# Altium schematic sheet pca20027 - radio.SchDoc
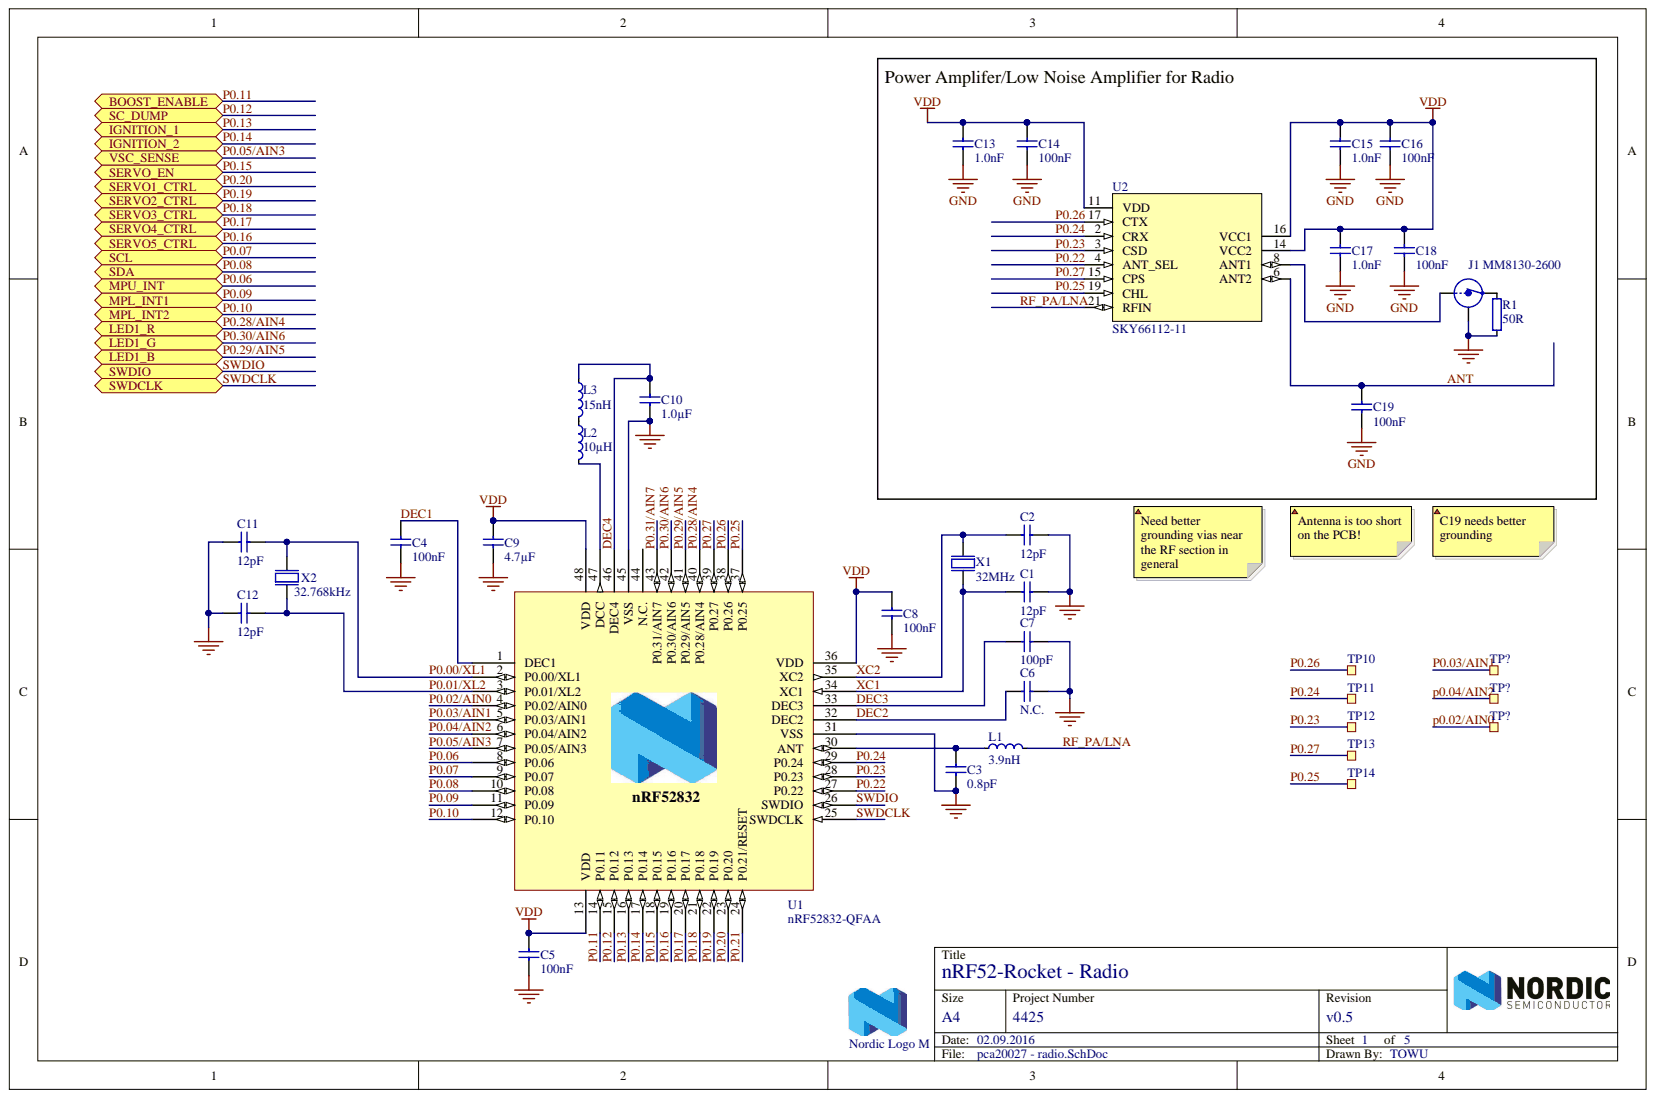
[diagram p0: pca20027 - radio.SchDoc]

## Parts
C1  12pF  [CAPC1005X04L]
C2  12pF  [CAPC1005X04L]
C3  0.8pF  [CAPC1005X04L]
C4  100nF  [CAPC1005X04L]
C5  100nF  [CAPC1005X04L]
C6  N.C.  [CAPC1005X04L]
C7  100pF  [CAPC1005X04L]
C8  100nF  [CAPC1005X04L]
C9  4.7µF  [CAPC1608X06L]
C10  1.0µF  [CAPC1608X06L]
C11  12pF  [CAPC1005X04L]
C12  12pF  [CAPC1005X04L]
C13  1.0nF  [CAPC1005X04L]
C14  100nF  [CAPC1005X04L]
C15  1.0nF  [CAPC1005X04L]
C16  100nF  [CAPC1005X04L]
C17  1.0nF  [CAPC1005X04L]
C18  100nF  [CAPC1005X04L]
C19  100nF  [CAPC1005X04L]
J1  MM8130-2600  [COAXIAL-SWF]
L1  3.9nH  [INDC1005X04L]
L2  10µH  [INDC1608X06L]
L3  15nH  [INDC1005X04L]
LOGO1  Nordic Logo M  [NORDIC_LOGO_M]  (no BOM)
R1  50R  [RESC1005X04L]
TP10  Ø1.0mm,SMD  [TP_SMD_Ø1.0mm]  (value not drawn)  (no BOM)
TP11  Ø1.0mm,SMD  [TP_SMD_Ø1.0mm]  (value not drawn)  (no BOM)
TP12  Ø1.0mm,SMD  [TP_SMD_Ø1.0mm]  (value not drawn)  (no BOM)
TP13  Ø1.0mm,SMD  [TP_SMD_Ø1.0mm]  (value not drawn)  (no BOM)
TP14  Ø1.0mm,SMD  [TP_SMD_Ø1.0mm]  (value not drawn)  (no BOM)
TP?  Ø1.0mm,SMD  [TP_SMD_Ø1.0mm]  (value not drawn)  (no BOM)
TP?  Ø1.0mm,SMD  [TP_SMD_Ø1.0mm]  (value not drawn)  (no BOM)
TP?  Ø1.0mm,SMD  [TP_SMD_Ø1.0mm]  (value not drawn)  (no BOM)
U1  nRF52832-QFAA  [QFN40P600X600X90-48N]
U2  SKY66112-11  [SKY66112-11]
X1  32MHz  [BT-XTAL_2016]
X2  32.768kHz  [XTAL_3215]

## Ports
BOOST_ENABLE, IGNITION_1, IGNITION_2, LED1_B, LED1_G, LED1_R, MPL_INT1, MPL_INT2, MPU_INT, SC_DUMP, SCL, SDA, SERVO1_CTRL, SERVO2_CTRL, SERVO3_CTRL, SERVO4_CTRL, SERVO5_CTRL, SERVO_EN, SWDCLK, SWDIO, VSC_SENSE

## Nets
GND: C1.2 C2.2 C3.1 C4.2 C5.2 C6.1 C7.2 C8.2 C9.2 C10.1 C11.2 C12.2 C13.1 C14.2 C15.1 C16.2 C17.1 C18.2 C19.2 J1.2 R1.1 U1.31(VSS) U1.45(VSS) U1.49 U2.1 U2.5 U2.7 U2.9 U2.10 U2.12 U2.13 U2.18 U2.20 U2.22 U2.23 X1.2 X1.4
VDD: C5.1 C8.1 C9.1 C13.2 C14.1 C15.2 C16.1 C17.2 C18.1 U1.13(VDD) U1.36(VDD) U1.48(VDD) U2.11(VDD) U2.14(VCC2) U2.16(VCC1)
ANT: C19.1 U2.6(ANT2)
DEC1: C4.1 U1.1(DEC1)
DEC2: C6.2 U1.32(DEC2)
DEC3: C7.1 U1.33(DEC3)
DEC4: C10.2 L3.1 U1.46(DEC4)
P0.00/XL1: C11.1 U1.2(P0.00/XL1) X2.1
P0.01/XL2: C12.1 U1.3(P0.01/XL2) X2.2
P0.02/AIN0: TP?.1 U1.4(P0.02/AIN0)
P0.03/AIN1: TP?.1 U1.5(P0.03/AIN1)
P0.04/AIN2: TP?.1 U1.6(P0.04/AIN2)
P0.05/AIN3: U1.7(P0.05/AIN3) ; port VSC_SENSE
P0.06: U1.8(P0.06) ; port MPU_INT
P0.07: U1.9(P0.07) ; port SCL
P0.08: U1.10(P0.08) ; port SDA
P0.09: U1.11(P0.09) ; port MPL_INT1
P0.10: U1.12(P0.10) ; port MPL_INT2
P0.11: U1.14(P0.11) ; port BOOST_ENABLE
P0.12: U1.15(P0.12) ; port SC_DUMP
P0.13: U1.16(P0.13) ; port IGNITION_1
P0.14: U1.17(P0.14) ; port IGNITION_2
P0.15: U1.18(P0.15) ; port SERVO_EN
P0.16: U1.19(P0.16) ; port SERVO5_CTRL
P0.17: U1.20(P0.17) ; port SERVO4_CTRL
P0.18: U1.21(P0.18) ; port SERVO3_CTRL
P0.19: U1.22(P0.19) ; port SERVO2_CTRL
P0.20: U1.23(P0.20) ; port SERVO1_CTRL
P0.21: U1.24(P0.21/RESET)
P0.22: U1.27(P0.22) U2.4(ANT_SEL)
P0.23: TP12.1 U1.28(P0.23) U2.3(CSD)
P0.24: TP11.1 U1.29(P0.24) U2.2(CRX)
P0.25: TP14.1 U1.37(P0.25) U2.19(CHL)
P0.26: TP10.1 U1.38(P0.26) U2.17(CTX)
P0.27: TP13.1 U1.39(P0.27) U2.15(CPS)
P0.28/AIN4: U1.40(P0.28/AIN4) ; port LED1_R
P0.29/AIN5: U1.41(P0.29/AIN5) ; port LED1_B
P0.30/AIN6: U1.42(P0.30/AIN6) ; port LED1_G
P0.31/AIN7: U1.43(P0.31/AIN7)
RF_PA/LNA: L1.2 U2.21(RFIN)
SWDCLK: U1.25(SWDCLK) ; port SWDCLK
SWDIO: U1.26(SWDIO) ; port SWDIO
XC1: C1.1 U1.34(XC1) X1.1
XC2: C2.1 U1.35(XC2) X1.3
NetC3_2: C3.2 L1.1 U1.30(ANT)
NetJ1_1: J1.1 U2.8(ANT1)
NetJ1_3: J1.3 R1.2
NetL2_1: L2.1 L3.2
NetL2_2: L2.2 U1.47(DCC)

Not connected: U1 44(N.C.)

## Library data of the parts
C1 C2 C11 C12 = C0402-12p: Capacitor, NP0, ±2%; Artikkelnr Noca: 20029159; Artikkelnr Simpro: N.A.; Database Table Name: Capacitors$; Note: 50V; Component Kind: Standard; Footprint Doc: 0402; LatestRevisionDate: 2011-05-11; Library Name: Nordic Passive DB.DbLib; Part Number: C0402-12p; Pin Count: 2; Published: 2009-05-08; Value: 12pF; Publisher: Nordic Semiconductor
C3 = C0402-0.8p: Capacitor, NP0, ±5%; Artikkelnr Noca: 20029147; Artikkelnr Simpro: N.A.; Database Table Name: Capacitors$; Note: 50V; Component Kind: Standard; Footprint Doc: 0402; LatestRevisionDate: 2012-11-21; Library Name: Nordic Passive DB.DbLib; Part Number: C0402-0.8p; Pin Count: 2; Published: 2009-05-08; Value: 0.8pF; Publisher: Nordic Semiconductor
C4 C5 C8 C14 C16 C18 C19 = C0402-100n: Capacitor, X7R, ±10%; Artikkelnr Noca: 20039200; Artikkelnr Simpro: N.A.; Database Table Name: Capacitors$; Note: 16V; Component Kind: Standard; Footprint Doc: 0402; LatestRevisionDate: 2009-11-23; Library Name: Nordic Passive DB.DbLib; Part Number: C0402-100n; Pin Count: 2; Published: 2009-05-08; Value: 100nF; Publisher: Nordic Semiconductor
C6 = C0402-N.C.: Not mounted; Artikkelnr Noca: N.A.; Database Table Name: Capacitors$; Note: Chip Cap; Artikkelnr Simpro: N.A.; Component Kind: Standard; Footprint Doc: 0402; LatestRevisionDate: 2009-05-08; Library Name: Nordic Passive DB.DbLib; Part Number: C0402-N.C.; Pin Count: 2; Published: 2009-05-08; Value: N.C.; Publisher: Nordic Semiconductor
C7 = C0402-100p: Capacitor, NP0, ±5%; Artikkelnr Noca: 20029135; Artikkelnr Simpro: N.A.; Database Table Name: Capacitors$; Note: 50V; Component Kind: Standard; Footprint Doc: 0402; LatestRevisionDate: 2009-05-08; Library Name: Nordic Passive DB.DbLib; Part Number: C0402-100p; Pin Count: 2; Published: 2009-05-08; Value: 100pF; Publisher: Nordic Semiconductor
C9 = C0603-4µ7: Capacitor, X5R, ±10%; Artikkelnr Noca: 20069060; Artikkelnr Simpro: N.A.; Database Table Name: Capacitors$; Note: 6.3V; Component Kind: Standard; Footprint Doc: 0603; LatestRevisionDate: 2009-11-23; Library Name: Nordic Passive DB.DbLib; Part Number: C0603-4µ7; Pin Count: 2; Published: 2009-05-08; Value: 4.7µF; Publisher: Nordic Semiconductor
C10 = C0603-1µ0: Capacitor, X7R, ±10%; Artikkelnr Noca: 20039335; Artikkelnr Simpro: N.A.; Database Table Name: Capacitors$; Note: 16V; Component Kind: Standard; Footprint Doc: 0603; LatestRevisionDate: 2013-06-05; Library Name: Nordic Passive DB.DbLib; Part Number: C0603-1µ0; Pin Count: 2; Published: 2009-05-08; Value: 1.0µF; Publisher: Nordic Semiconductor
C13 C15 C17 = C0402-1n0: Capacitor, X7R, ±10%; Artikkelnr Noca: 20039223; Artikkelnr Simpro: N.A.; Database Table Name: Capacitors$; Note: 50V; Component Kind: Standard; Footprint Doc: 0402; LatestRevisionDate: 2009-11-23; Library Name: Nordic Passive DB.DbLib; Part Number: C0402-1n0; Pin Count: 2; Published: 2009-05-08; Value: 1.0nF; Publisher: Nordic Semiconductor
J1 = MM8130-2600: Coaxial Connector with Switch; 2nd source part number: 81-MM8130-2600RA2; Manufacturer: Murata; Artikkelnr Noca: 55029011; Artikkelnr Simpro: N.A.; Database Table Name: Connectors$; 2nd source Supplier: Mouser; Component Kind: Standard; Datasheet: http://www.murata.com/products/catalog/pdf/o33e.pdf; Footprint Doc: COAXIAL-SWF; LatestRevisionDate: 2014-04-24; Library Name: Nordic Passive DB.DbLib; Manufacturer Part Number: MM8130-2600; Part Number: MM8130-2600; Pin Count: 3; Published: 2014-04-24; Supplier: Digi-Key; Supplier part number: 490-4981-1-ND; Value: MM8130-2600; Publisher: Nordic Semiconductor
L1 = L0402-3n9: High frequency chip inductor ±5%; Manufacturer: Murata; Manufacturer Part Number: LQP15MN3N9B02D; Artikkelnr Noca: 33029151; Artikkelnr Simpro: N.A.; Database Table Name: Inductors$; Component Kind: Standard; Datasheet: http://search.murata.co.jp/Ceramy/image/img/PDF/ENG/L0075S0084LQP15M.pdf; Footprint Doc: 0402; LatestRevisionDate: 2012-09-19; Library Name: Nordic Passive DB.DbLib; Note: High frequency chip inductor ±5%; Part Number: L0402-3n9; Pin Count: 2; Published: 2009-05-08; Supplier: Digi-Key; Supplier part number: 490-1131-1-ND; Value: 3.9nH; Publisher: Nordic Semiconductor
L2 = L0603-10µ: Chip inductor, IDC,min = 50 mA, ±20%; 2nd source part number: 178-6105; Manufacturer: Taiyo Yuden; Manufacturer Part Number: LBMF1608T100K; Artikkelnr Noca: 33029314; Artikkelnr Simpro: N.A.; Database Table Name: Inductors$; Note: LBMF1608T100K 10µH 80mA 0603; 2nd source Supplier: Farnell; Component Kind: Standard; Datasheet: http://www.yuden.co.jp/ut/product/pdf/elb2_e.pdf; Footprint Doc: 0603; LatestRevisionDate: 2012-09-19; Library Name: Nordic Passive DB.DbLib; Part Number: L0603-10µ; Pin Count: 2; Published: 2010-02-24; Supplier: Digi-Key; Supplier part number: 587-1714-1-ND; Value: 10µH; Publisher: Nordic Semiconductor
L3 = L0402-15n: High frequency chip inductor ±10%; 2nd source part number: 658-1328; Manufacturer: Taiyo Yuden; Manufacturer Part Number: HK100515NJ-T; Artikkelnr Noca: 33029323; Artikkelnr Simpro: N.A.; Database Table Name: Inductors$; 2nd source Supplier: Farnell; Component Kind: Standard; Datasheet: http://www.yuden.co.jp/productdata/catalog/en/mlci04_e.pdf; Footprint Doc: 0402; LatestRevisionDate: 2012-09-19; Library Name: Nordic Passive DB.DbLib; Note: High frequency chip inductor ±10%; Part Number: L0402-15n; Pin Count: 2; Published: 2010-07-12; Supplier: Digi-Key; Supplier part number: 587-1521-1-ND; Value: 15nH; Publisher: Nordic Semiconductor
LOGO1 = Nordic Logo M: Nordic Logo, medium size; Artikkelnr Noca: N.A.; Database Table Name: Connectors$; Artikkelnr Simpro: N.A.; Footprint Doc: NORDIC_LOGO_M; LatestRevisionDate: 2010-02-18; Library Name: Nordic Passive DB.DbLib; Part Number: Nordic Logo M; Pin Count: 2; Published: 2010-02-18; Value: Nordic Logo M; Publisher: Nordic Semiconductor
R1 = R0402-50R: Resistor, ±1%, 0.063W; Artikkelnr Noca: N.A.; Database Table Name: Resistors$; Note: Chip Res 1%; Value Ohm: 50; Artikkelnr Simpro: N.A.; Component Kind: Standard; Footprint Doc: 0402; LatestRevisionDate: 2014-09-03; Library Name: Nordic Passive DB.DbLib; Part Number: R0402-50R; Pin Count: 2; Published: 2014-09-03; Value: 50R; Publisher: Nordic Semiconductor
TP10-TP14 TP? TP? TP? = TP_SMD_Ø1.0MM: 1.0mm circular SMD testpad; Manufacturer: Nordic Semiconductor; Artikkelnr Noca: N.A.; Database Table Name: Connectors$; Artikkelnr Simpro: N.A.; Footprint Doc: TP_SMD_Ø1.0mm; LatestRevisionDate: 2013-06-11; Library Name: Nordic Passive DB.DbLib; Part Number: TP_SMD_Ø1.0MM; Pin Count: 1; Published: 2013-06-11; Value: Ø1.0mm,SMD; Publisher: Nordic Semiconductor
U1 = nRF52832-QFAA: Multi-protocol Bluetooth Low Energy and 2.4GHz proprietary system-on-chip; Manufacturer: Nordic Semiconductor; Artikkelnr Noca: N.A.; Database Table Name: nRF Devices$; Artikkelnr Simpro: N.A.; Component Kind: Standard; Footprint Doc: QFN-48; LatestRevisionDate: 2014-11-26; Library Name: Nordic Active DB.DbLib; Manufacturer Part Number: nRF52832-QFAA; Part Number: nRF52832-QFAA; Pin Count: 48; Published: 2014-11-26; Supplier: Nordic Semiconductor; Value: nRF52832-QFAA; Publisher: Nordic Semiconductor
U2 = SKY66112-11: 2.4 GHz ZigBee®/Thread/Bluetooth® Smart Front-End Module; Manufacturer: Skyworks; Artikkelnr Noca: N.A.; Database Table Name: Misc IC$; Artikkelnr Simpro: N.A.; Component Kind: Standard; Datasheet: *Not available Online Yet; Footprint Doc: SKY66112-11; LatestRevisionDate: 2016-07-06; Library Name: Nordic Active DB.DbLib; Manufacturer Part Number: SKY66112-11; Part Number: SKY66112-11; Pin Count: 22; Published: 2016-07-06; Supplier: Skyworks; Supplier part number: SKY66112-11; Value: SKY66112-11; Publisher: Nordic Semiconductor
X1 = XTAL_SMD2016_32MHz_8pF_Epson: XTAL SMD 2016, 32MHz, Cl=8pF, Total Tol: ±40ppm; Manufacturer: Epson Toyocom; Manufacturer Part Number: Q22FA12800025; Artikkelnr Noca: N.A.; Database Table Name: Crystal_Osc$; Note: XTAL SMD 32.000MHz; Artikkelnr Simpro: N.A.; Component Kind: Standard; Datasheet: http://www.epsondevice.com/docs/qd/en/DownloadServlet?id=ID000449; Footprint Doc: XTAL_2016; LatestRevisionDate: 2014-11-27; Library Name: Nordic Discrete DB.DbLib; Part Number: XTAL_SMD2016_32MHz_8pF_Epson; Pin Count: 4; Published: 2012-03-09; Supplier: Farnell; Supplier part number: 171-2806; Value: 32MHz; Publisher: Nordic Semiconductor
X2 = XTAL_FC-135 32.768k: XTAL SMD 3215, 32.768kHz, 9pF, ±20ppm; 2nd source part number: 127-8037; Manufacturer: Epson Toyocom; Manufacturer Part Number: FC-135 32.768KHZ ±20PPM,9.0PF; Artikkelnr Noca: 22019091; Artikkelnr Simpro: N.A.; Database Table Name: Crystal_Osc$; Note: XTAL SMD 32.768kHz, 3215; 2nd source Supplier: Farnell; Component Kind: Standard; Datasheet: http://www.farnell.com/datasheets/57480.pdf; Footprint Doc: XTAL_3215; LatestRevisionDate: 2014-03-27; Library Name: Nordic Discrete DB.DbLib; Part Number: XTAL_FC-135 32.768k; Pin Count: 2; Published: 2010-10-18; Supplier: Digi-Key; Supplier part number: FC-135 32.7680KA-AC-ND; Value: 32.768kHz; Publisher: Nordic Semiconductor
